# Altium schematic sheet Модуль_p2.SchDoc(B1)
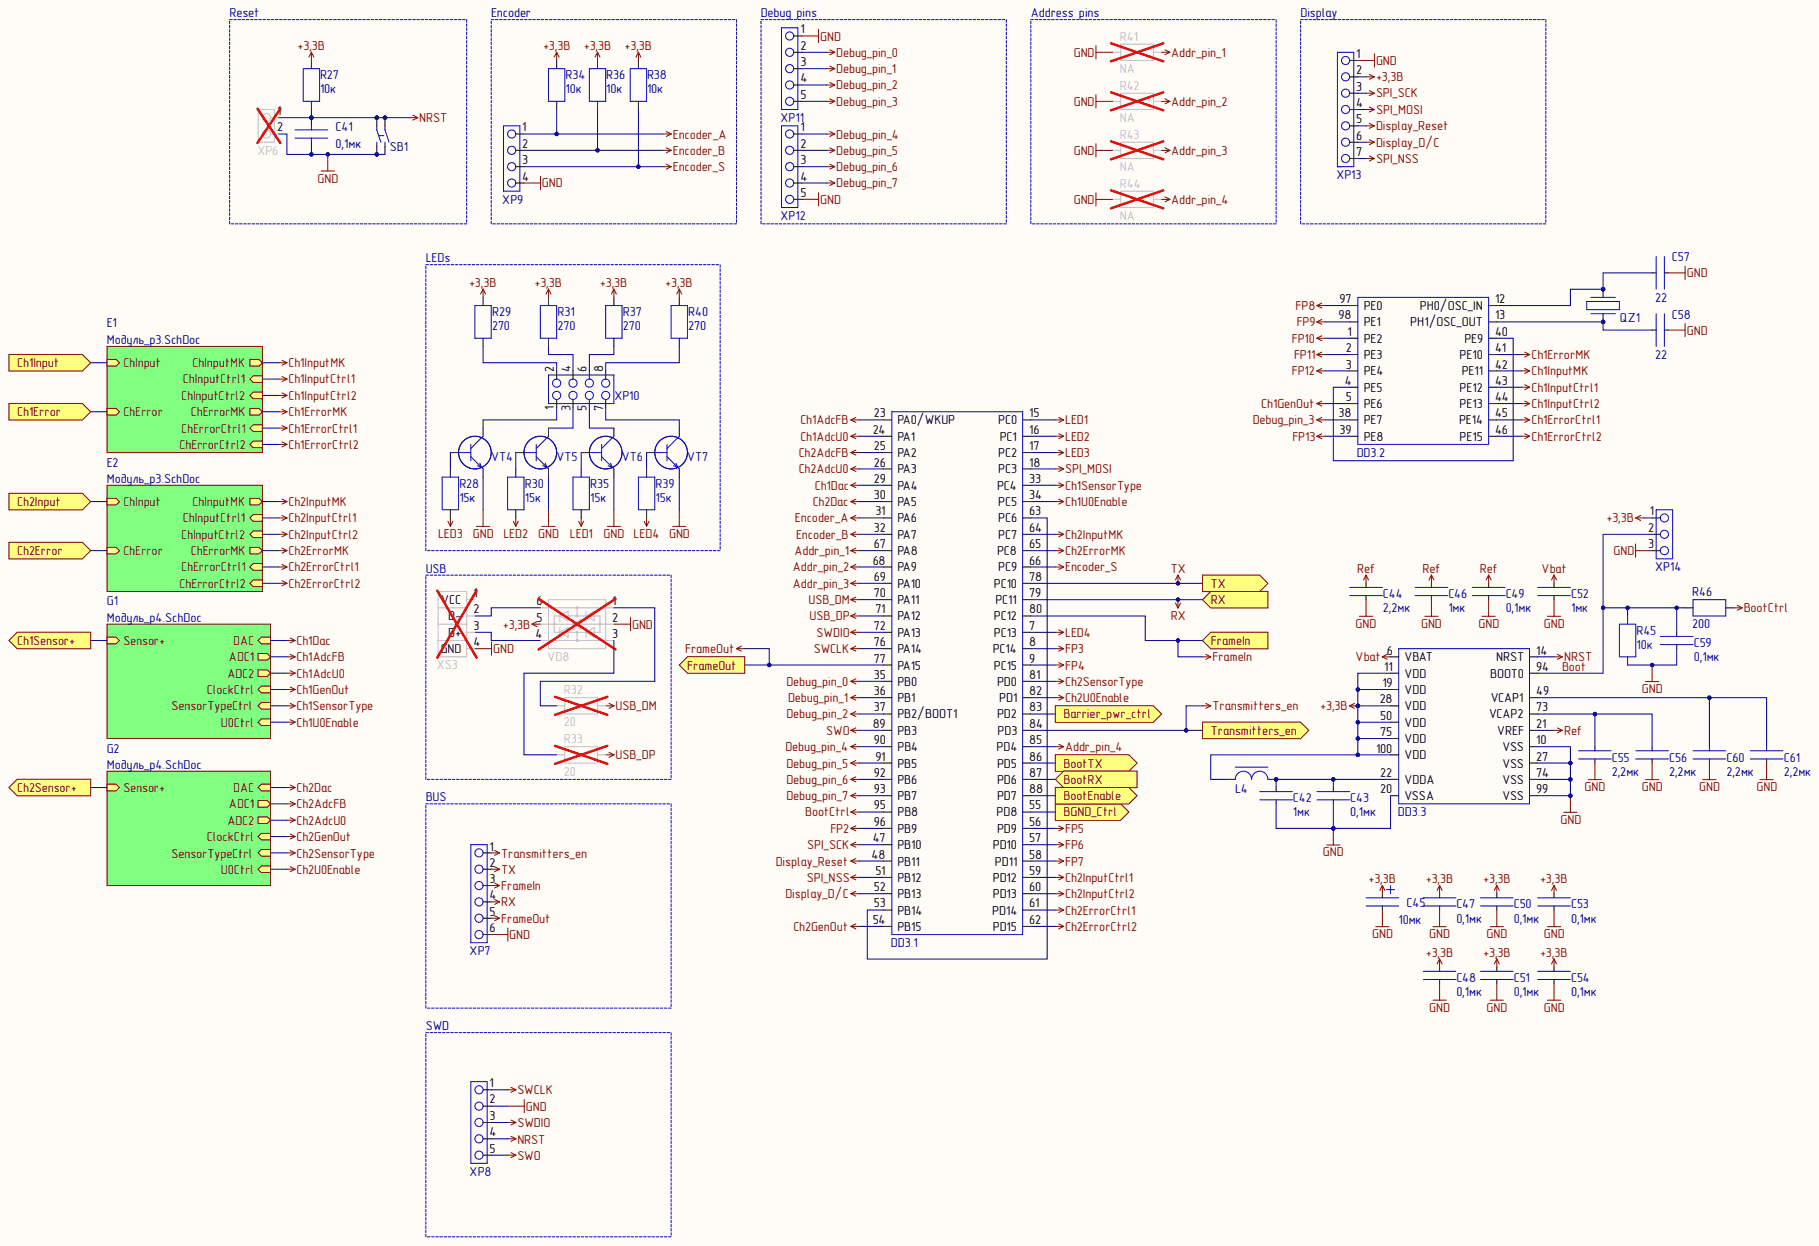
[diagram p0: Модуль_p2.SchDoc]

## Parts
C41  value 0,1мк  [C_0805]  (hidden comment: C_0805)
C42  value 1мк  [C_0805]  (hidden comment: C_0805)
C43  value 0,1мк  [C_0805]  (hidden comment: C_0805)
C44  value 2,2мк  [C_0805]  (hidden comment: C_0805)
C45  value 10мк  [C_TAN_B]  (hidden comment: C_TAN_B)
C46  value 1мк  [C_0805]  (hidden comment: C_0805)
C47  value 0,1мк  [C_0805]  (hidden comment: C_0805)
C48  value 0,1мк  [C_0805]  (hidden comment: C_0805)
C49  value 0,1мк  [C_0805]  (hidden comment: C_0805)
C50  value 0,1мк  [C_0805]  (hidden comment: C_0805)
C51  value 0,1мк  [C_0805]  (hidden comment: C_0805)
C52  value 1мк  [C_0805]  (hidden comment: C_0805)
C53  value 0,1мк  [C_0805]  (hidden comment: C_0805)
C54  value 0,1мк  [C_0805]  (hidden comment: C_0805)
C55  value 2,2мк  [C_0805]  (hidden comment: C_0805)
C56  value 2,2мк  [C_0805]  (hidden comment: C_0805)
C57  value 22  [C_0805]  (hidden comment: C_0805)
C58  value 22  [C_0805]  (hidden comment: C_0805)
C59  value 0,1мк  [C_0805]  (hidden comment: C_0805)
C60  value 2,2мк  [C_0805]  (hidden comment: C_0805)
C61  value 2,2мк  [C_0805]  (hidden comment: C_0805)
DD3  STM32F429VG  [LQFP100]  (value not drawn)  (parts .1,.2,.3)
L4  BLM21xx  [R_0805]  (value not drawn)
QZ1  KX-K(S)T  [KX-K(S)T]  (value not drawn)
R27  value 10к  [R_0805]  (hidden comment: R_0805)
R28  value 15к  [R_0805]  (hidden comment: R_0805)
R29  value 270  [R_0805]  (hidden comment: R_0805)
R30  value 15к  [R_0805]  (hidden comment: R_0805)
R31  value 270  [R_0805]  (hidden comment: R_0805)
R32  value 20  [R_0805]  (hidden comment: R_0805)
R33  value 20  [R_0805]  (hidden comment: R_0805)
R34  value 10к  [R_0805]  (hidden comment: R_0805)
R35  value 15к  [R_0805]  (hidden comment: R_0805)
R36  value 10к  [R_0805]  (hidden comment: R_0805)
R37  value 270  [R_0805]  (hidden comment: R_0805)
R38  value 10к  [R_0805]  (hidden comment: R_0805)
R39  value 15к  [R_0805]  (hidden comment: R_0805)
R40  value 270  [R_0805]  (hidden comment: R_0805)
R41  value NA  [R_0805]  (hidden comment: R_0805)
R42  value NA  [R_0805]  (hidden comment: R_0805)
R43  value NA  [R_0805]  (hidden comment: R_0805)
R44  value NA  [R_0805]  (hidden comment: R_0805)
R45  value 10к  [R_0805]  (hidden comment: R_0805)
R46  value 200  [R_0805]  (hidden comment: R_0805)
SB1  IT-1102S  [SW_6x6]  (value not drawn)
VD8  USBLC6  [SOT23-6]  (value not drawn)
VT4  BC847  [SOT23]  (value not drawn)
VT5  BC847  [SOT23]  (value not drawn)
VT6  BC847  [SOT23]  (value not drawn)
VT7  BC847  [SOT23]  (value not drawn)
XP6  PLS-2  [PLS-2]  (value not drawn)
XP7  PLS-6  [PLS-6]  (value not drawn)
XP8  PLS-5  [PLS-5]  (value not drawn)
XP9  PLS-4  [PLS-4]  (value not drawn)
XP10  PLD-8  [PLD-8]  (value not drawn)
XP11  PLS-5  [PLS-5]  (value not drawn)
XP12  PLS-5  [PLS-5]  (value not drawn)
XP13  PLS-7  [PLS-7]  (value not drawn)
XP14  PLS-3  [PLS-3]  (value not drawn)
XS3  USB_Type_B_DS1099  [USB Type B]  (value not drawn)

## Ports
Barrier_pwr_ctrl, BGND_Ctrl, BootEnable, BootRX, BootTX, Ch1Error, Ch1Input, Ch1Sensor+, Ch2Error, Ch2Input, Ch2Sensor+, FrameIn, FrameOut, RX, Transmitters_en, TX

## Nets
+3,3В: C45.2 C47.2 C48.2 C50.2 C51.2 C53.2 C54.2 DD3.11(VDD) DD3.19(VDD) DD3.28(VDD) DD3.50(VDD) DD3.75(VDD) DD3.100(VDD) L4.1 R27.2 R29.1 R31.1 R34.1 R36.1 R37.1 R38.1 R40.1 VD8.5 XP13.2 XP14.1
Addr_pin_1: DD3.67(PA8) R41.1
Addr_pin_2: DD3.68(PA9) R42.1
Addr_pin_3: DD3.69(PA10) R43.1
Addr_pin_4: DD3.85(PD4) R44.1
BootCtrl: DD3.95(PB8) R46.2
Ch1AdcFB: DD3.23(PA0/WKUP)
Ch1AdcU0: DD3.24(PA1)
Ch1Dac: DD3.29(PA4)
Ch1ErrorCtrl1: DD3.45(PE14)
Ch1ErrorCtrl2: DD3.46(PE15)
Ch1ErrorMK: DD3.41(PE10)
Ch1GenOut: DD3.5(PE6)
Ch1InputCtrl1: DD3.43(PE12)
Ch1InputCtrl2: DD3.44(PE13)
Ch1InputMK: DD3.42(PE11)
Ch1SensorType: DD3.33(PC4)
Ch1U0Enable: DD3.34(PC5)
Ch2AdcFB: DD3.25(PA2)
Ch2AdcU0: DD3.26(PA3)
Ch2Dac: DD3.30(PA5)
Ch2ErrorCtrl1: DD3.61(PD14)
Ch2ErrorCtrl2: DD3.62(PD15)
Ch2ErrorMK: DD3.65(PC8)
Ch2GenOut: DD3.54(PB15)
Ch2InputCtrl1: DD3.59(PD12)
Ch2InputCtrl2: DD3.60(PD13)
Ch2InputMK: DD3.64(PC7)
Ch2SensorType: DD3.81(PD0)
Ch2U0Enable: DD3.82(PD1)
Debug_pin_0: DD3.35(PB0) XP11.2
Debug_pin_1: DD3.36(PB1) XP11.3
Debug_pin_2: DD3.37(PB2/BOOT1) XP11.4
Debug_pin_3: DD3.38(PE7) XP11.5
Debug_pin_4: DD3.90(PB4) XP12.1
Debug_pin_5: DD3.91(PB5) XP12.2
Debug_pin_6: DD3.92(PB6) XP12.3
Debug_pin_7: DD3.93(PB7) XP12.4
Display_D/C: DD3.52(PB13) XP13.6
Display_Reset: DD3.48(PB11) XP13.5
Encoder_A: DD3.31(PA6) R34.2 XP9.1
Encoder_B: DD3.32(PA7) R36.2 XP9.2
Encoder_S: DD3.66(PC9) R38.2 XP9.3
FP2: DD3.96(PB9)
FP3: DD3.8(PC14)
FP4: DD3.9(PC15)
FP5: DD3.56(PD9)
FP6: DD3.57(PD10)
FP7: DD3.58(PD11)
FP8: DD3.97(PE0)
FP9: DD3.98(PE1)
FP10: DD3.1(PE2)
FP11: DD3.2(PE3)
FP12: DD3.3(PE4)
FP13: DD3.39(PE8)
GND: C41.1 C42.1 C43.1 C44.1 C45.1 C46.1 C47.1 C48.1 C49.1 C50.1 C51.1 C52.1 C53.1 C54.1 C55.1 C56.1 C57.1 C58.1 C59.1 C60.1 C61.1 DD3.10(VSS) DD3.20(VSSA) DD3.27(VSS) DD3.74(VSS) DD3.99(VSS) R41.2 R42.2 R43.2 R44.2 R45.2 SB1.3 SB1.4 VD8.2 VT4.2 VT5.2 VT6.2 VT7.2 XP6.2 XP7.6 XP8.2 XP9.4 XP11.1 XP12.5 XP13.1 XP14.3 XS3.4(GND)
LED1: DD3.15(PC0) R35.2
LED2: DD3.16(PC1) R30.2
LED3: DD3.17(PC2) R28.2
LED4: DD3.7(PC13) R39.2
NRST: C41.2 DD3.14(NRST) R27.1 SB1.1 SB1.2 XP6.1 XP8.4
Ref: C44.2 C46.2 C49.2 DD3.21(VREF)
SPI_MOSI: DD3.18(PC3) XP13.4
SPI_NSS: DD3.51(PB12) XP13.7
SPI_SCK: DD3.47(PB10) XP13.3
SWCLK: DD3.76(PA14) XP8.1
SWDIO: DD3.72(PA13) XP8.3
SWO: DD3.89(PB3) XP8.5
USB_DM: DD3.70(PA11) R32.1
USB_DP: DD3.71(PA12) R33.1
Vbat: C52.2 DD3.6(VBAT)
Barrier_pwr_ctrl: DD3.83(PD2) ; port Barrier_pwr_ctrl
BGND_Ctrl: DD3.55(PD8) ; port BGND_Ctrl
Boot: C59.2 DD3.94(BOOT0) R45.1 R46.1 XP14.2
BootEnable: DD3.88(PD7) ; port BootEnable
BootRX: DD3.87(PD6) ; port BootRX
BootTX: DD3.86(PD5) ; port BootTX
FrameIn: DD3.80(PC12) XP7.3 ; port FrameIn
FrameOut: DD3.77(PA15) XP7.5 ; port FrameOut
RX: DD3.79(PC11) XP7.4 ; port RX
Transmitters_en: DD3.84(PD3) XP7.1 ; port Transmitters_en
TX: DD3.78(PC10) XP7.2 ; port TX
NetC42_2: C42.2 C43.2 DD3.22(VDDA) L4.2
NetC55_2: C55.2 C56.2 DD3.73(VCAP2)
NetC57_2: C57.2 DD3.12(PH0/OSC_IN) QZ1.2
NetC58_2: C58.2 DD3.13(PH1/OSC_OUT) QZ1.1
NetC60_2: C60.2 C61.2 DD3.49(VCAP1)
NetDD3_4: DD3.4(PE5) DD3.40(PE9)
NetDD3_53: DD3.53(PB14) DD3.63(PC6)
NetR28_1: R28.1 VT4.1
NetR29_2: R29.2 XP10.2
NetR30_1: R30.1 VT5.1
NetR31_2: R31.2 XP10.4
NetR32_2: R32.2 VD8.1
NetR33_2: R33.2 VD8.3
NetR35_1: R35.1 VT6.1
NetR37_2: R37.2 XP10.6
NetR39_1: R39.1 VT7.1
NetR40_2: R40.2 XP10.8
NetVD8_4: VD8.4 XS3.3(D+)
NetVD8_6: VD8.6 XS3.2(D-)
NetVT4_3: VT4.3 XP10.1
NetVT5_3: VT5.3 XP10.3
NetVT6_3: VT6.3 XP10.5
NetVT7_3: VT7.3 XP10.7
NetXS3_1: XS3.1(VCC)
ChError: ; port Ch1Error, port Ch2Error
ChInput: ; port Ch1Input, port Ch2Input
Sensor+: ; port Ch1Sensor+, port Ch2Sensor+

## Library data of the parts
C41-C44 C46-C61 = C_0805: Конденсатор чип 0805; Наименование: Конденсатор 0805 (X7R,Y5V,NPO); Component Kind: Standard; Library Name: All_design_lib.IntLib
C45 = C_TAN_B: Конденсатор танталовый тип В; Component Kind: Standard; Library Name: All_design_lib.IntLib; Наименование: Конденсатор танталовый тип В
DD3 = STM32F429VG: Микроконтроллер STM32F429VG; Component Kind: Standard; Library Name: All_design_lib.IntLib; Наименование: Микроконтроллер STM32F429VG
L4 = BLM21xx: Ферритовый фильтр; Наименование: Ферритовый фильтр BLM21xx; Component Kind: Standard; Library Name: All_design_lib.IntLib
QZ1 = KX-K(S)T: Кварцевый резонатор; Наименование: Кварцевый резонатор KX-KST 8 МГц; Component Kind: Standard; Library Name: All_design_lib.SchLib
R27-R46 = R_0805: Резистор чип 0805; Наименование: Резистор 0805 0,125 Вт; Component Kind: Standard; Library Name: All_design_lib.IntLib
SB1 = IT-1102S: Кнопка тактовая; Наименование: Кнопка IT-1102S; Component Kind: Standard; Library Name: All_design_lib.IntLib
VD8 = USBLC6: ESD защита для USB; Наименование: Микросхема USBLC6; Component Kind: Standard; Library Name: All_design_lib.IntLib
VT4-VT7 = BC847: Транзитор биполярный NPN, 50 В 100 мА; Наименование: Транзистор BC847; Component Kind: Standard; Library Name: All_design_lib.IntLib
XP6 = PLS-2: Разъем штыревой однорядный; Наименование: Разъем PLS-40 2 pin; Component Kind: Standard; Library Name: All_design_lib.IntLib
XP7 = PLS-6: Разъем штыревой однорядный; Наименование: Разъем PLS-40 6 pin; Component Kind: Standard; Library Name: All_design_lib.IntLib
XP8 XP11 XP12 = PLS-5: Разъем штыревой однорядный; Наименование: Разъем PLS-40 5 pin; Component Kind: Standard; Library Name: All_design_lib.IntLib
XP9 = PLS-4: Разъем штыревой однорядный; Наименование: Разъем PLS-40 4 pin; Component Kind: Standard; Library Name: All_design_lib.IntLib
XP10 = PLD-8: Разъем штыревой однорядный; Наименование: Разъем PLD-80 8 pin; Component Kind: Standard; Library Name: All_design_lib.IntLib
XP13 = PLS-7: Разъем штыревой однорядный; Наименование: Разъем PLS-40 7 pin; Component Kind: Standard; Library Name: All_design_lib.IntLib
XP14 = PLS-3: Разъем штыревой однорядный; Наименование: Разъем PLS-40 3 pin; Component Kind: Standard; Library Name: All_design_lib.IntLib
XS3 = USB_Type_B_DS1099: Разъем USB тип B на плату; Наименование: Разъем DS1099, USB Type B; Component Kind: Standard; Library Name: All_design_lib.IntLib
